# Altium schematic sheet cwh_fpga_pwr.SchDoc
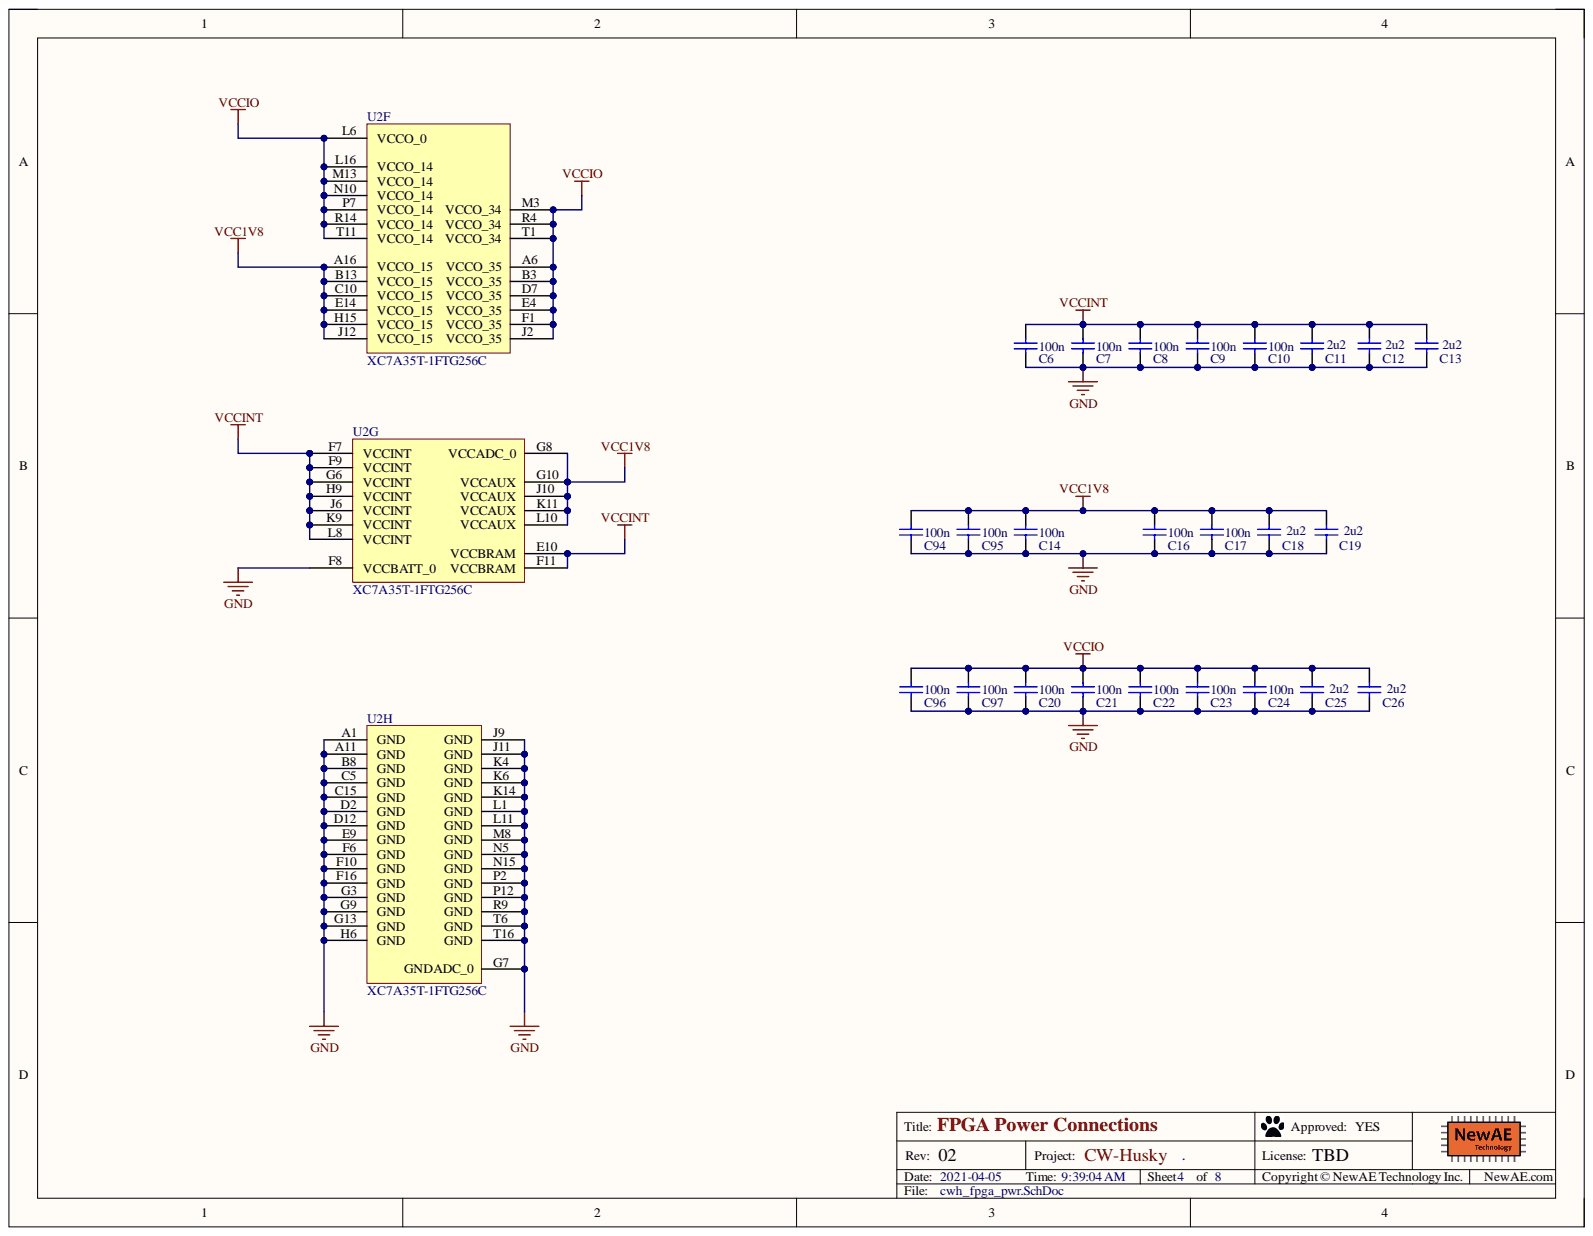
[diagram p0: cwh_fpga_pwr.SchDoc]

## Parts
C6  100n  [0402]
C7  100n  [0402]
C8  100n  [0402]
C9  100n  [0402]
C10  100n  [0402]
C11  2u2  [0603]
C12  2u2  [0603]
C13  2u2  [0603]
C14  100n  [0402]
C16  100n  [0402]
C17  100n  [0402]
C18  2u2  [0603]
C19  2u2  [0603]
C20  100n  [0402]
C21  100n  [0402]
C22  100n  [0402]
C23  100n  [0402]
C24  100n  [0402]
C25  2u2  [0603]
C26  2u2  [0603]
C94  100n  [0402]
C95  100n  [0402]
C96  100n  [0402]
C97  100n  [0402]
U2  XC7A35T-1FTG256C  [FTG256]  (parts F,G,H)

## Nets
GND: C6.2 C7.2 C8.2 C9.2 C10.2 C11.2 C12.2 C13.2 C14.2 C16.2 C17.2 C18.2 C19.2 C20.2 C21.2 C22.2 C23.2 C24.2 C25.2 C26.2 C94.2 C95.2 C96.2 C97.2 U2.A1(GND) U2.A11(GND) U2.B8(GND) U2.C5(GND) U2.C15(GND) U2.D2(GND) U2.D12(GND) U2.E9(GND) U2.F6(GND) U2.F8(VCCBATT_0) U2.F10(GND) U2.F16(GND) U2.G3(GND) U2.G7(GNDADC_0) U2.G9(GND) U2.G13(GND) U2.H6(GND) U2.J9(GND) U2.J11(GND) U2.K4(GND) U2.K6(GND) U2.K14(GND) U2.L1(GND) U2.L11(GND) U2.M8(GND) U2.N5(GND) U2.N15(GND) U2.P2(GND) U2.P12(GND) U2.R9(GND) U2.T6(GND) U2.T16(GND)
VCC1V8: C14.1 C16.1 C17.1 C18.1 C19.1 C94.1 C95.1 U2.A16(VCCO_15) U2.B13(VCCO_15) U2.C10(VCCO_15) U2.E14(VCCO_15) U2.G8(VCCADC_0) U2.G10(VCCAUX) U2.H15(VCCO_15) U2.J10(VCCAUX) U2.J12(VCCO_15) U2.K11(VCCAUX) U2.L10(VCCAUX)
VCCINT: C6.1 C7.1 C8.1 C9.1 C10.1 C11.1 C12.1 C13.1 U2.E10(VCCBRAM) U2.F7(VCCINT) U2.F9(VCCINT) U2.F11(VCCBRAM) U2.G6(VCCINT) U2.H9(VCCINT) U2.J6(VCCINT) U2.K9(VCCINT) U2.L8(VCCINT)
VCCIO: C20.1 C21.1 C22.1 C23.1 C24.1 C25.1 C26.1 C96.1 C97.1 U2.A6(VCCO_35) U2.B3(VCCO_35) U2.D7(VCCO_35) U2.E4(VCCO_35) U2.F1(VCCO_35) U2.J2(VCCO_35) U2.L6(VCCO_0) U2.L16(VCCO_14) U2.M3(VCCO_34) U2.M13(VCCO_14) U2.N10(VCCO_14) U2.P7(VCCO_14) U2.R4(VCCO_34) U2.R14(VCCO_14) U2.T1(VCCO_34) U2.T11(VCCO_14)

## Library data of the parts
C6-C10 C14 C16 C17 C20-C24 C94-C97 = CCHIP-100n-0402: 100n, 0402; Manufacture Part Number 1: CGA2B3X7R1V104K050BB; Component Group: Capacitor; Database Table Name: main; Case-EIA: 0402; Component Kind: Standard; Component Type: Standard; Library Name: NewAE_AltiumLib.DBLib; Mounting Technology: SMT; Part Number: CCHIP-100n-0402; Pin Count: 2; Supplier 1: Digi-Key; Supplier Part Number 1: 445-6901-1-ND; Value: 100n
C11-C13 C18 C19 C25 C26 = CCHIP-2u2-0603: 2u2, 0603; Manufacture 1: Samsung; Manufacture Part Number 1: CL10B225KP8NNNC; Case-Metric: 1608; Component Group: Capacitor; Database Table Name: main; Rated Voltage: 10VDC; Case-EIA: 0603; Component Kind: Standard; Component Type: Standard; Library Name: NewAE_AltiumLib.DBLib; Mounting Technology: SMT; Part Number: CCHIP-2u2-0603; Pin Count: 2; Supplier 1: Digi-Key; Supplier Part Number 1: 1276-1134-2-ND; Value: 2u2
U2 = CMP-0383-00028-1: Artix-7 FPGA, 170 User I/Os, 0 GTP, 256-Ball BGA, Speed Grade 1, Commercial Grade, Pb-Free; Manufacturer: Xilinx; Available User I/O: 170; CMTs: 5; GTP: 0; Speed Grades: 1; Component Kind: Standard; ComponentLink1Description: Manufacturer URL; ComponentLink1URL: http://www.xilinx.com/; ComponentLink2Description: Datasheet; ComponentLink2URL: http://www.xilinx.com/support/documentation/data_sheets/ds180_7Series_Overview.pdf; ComponentLink3Description: Pinout; ComponentLink3URL: http://www.xilinx.com/support/documentation/user_guides/ug475_7Series_Pkg_Pinout.pdf; ComponentLink4Description: Package Specification; ComponentLink4URL: http://www.xilinx.com/support/documentation/user_guides/ug475_7Series_Pkg_Pinout.pdf; DatasheetVersion: Rev. 1.15; Library Name: Altium Content Vault; Mounting Technology: Surface Mount; Operating Temperature Range: Commercial; PackageDescription: 256-Ball Wire-Bond Fine-Pitch Thin BGA, Body 17 x 17 mm, Pitch 1 mm; PackageReference: FTG-256; PackageVersion: Rev. 1.11; PartNumber: XC7A35T-1FTG256C
